# Altium schematic sheet Top.SchDoc(U_Top)
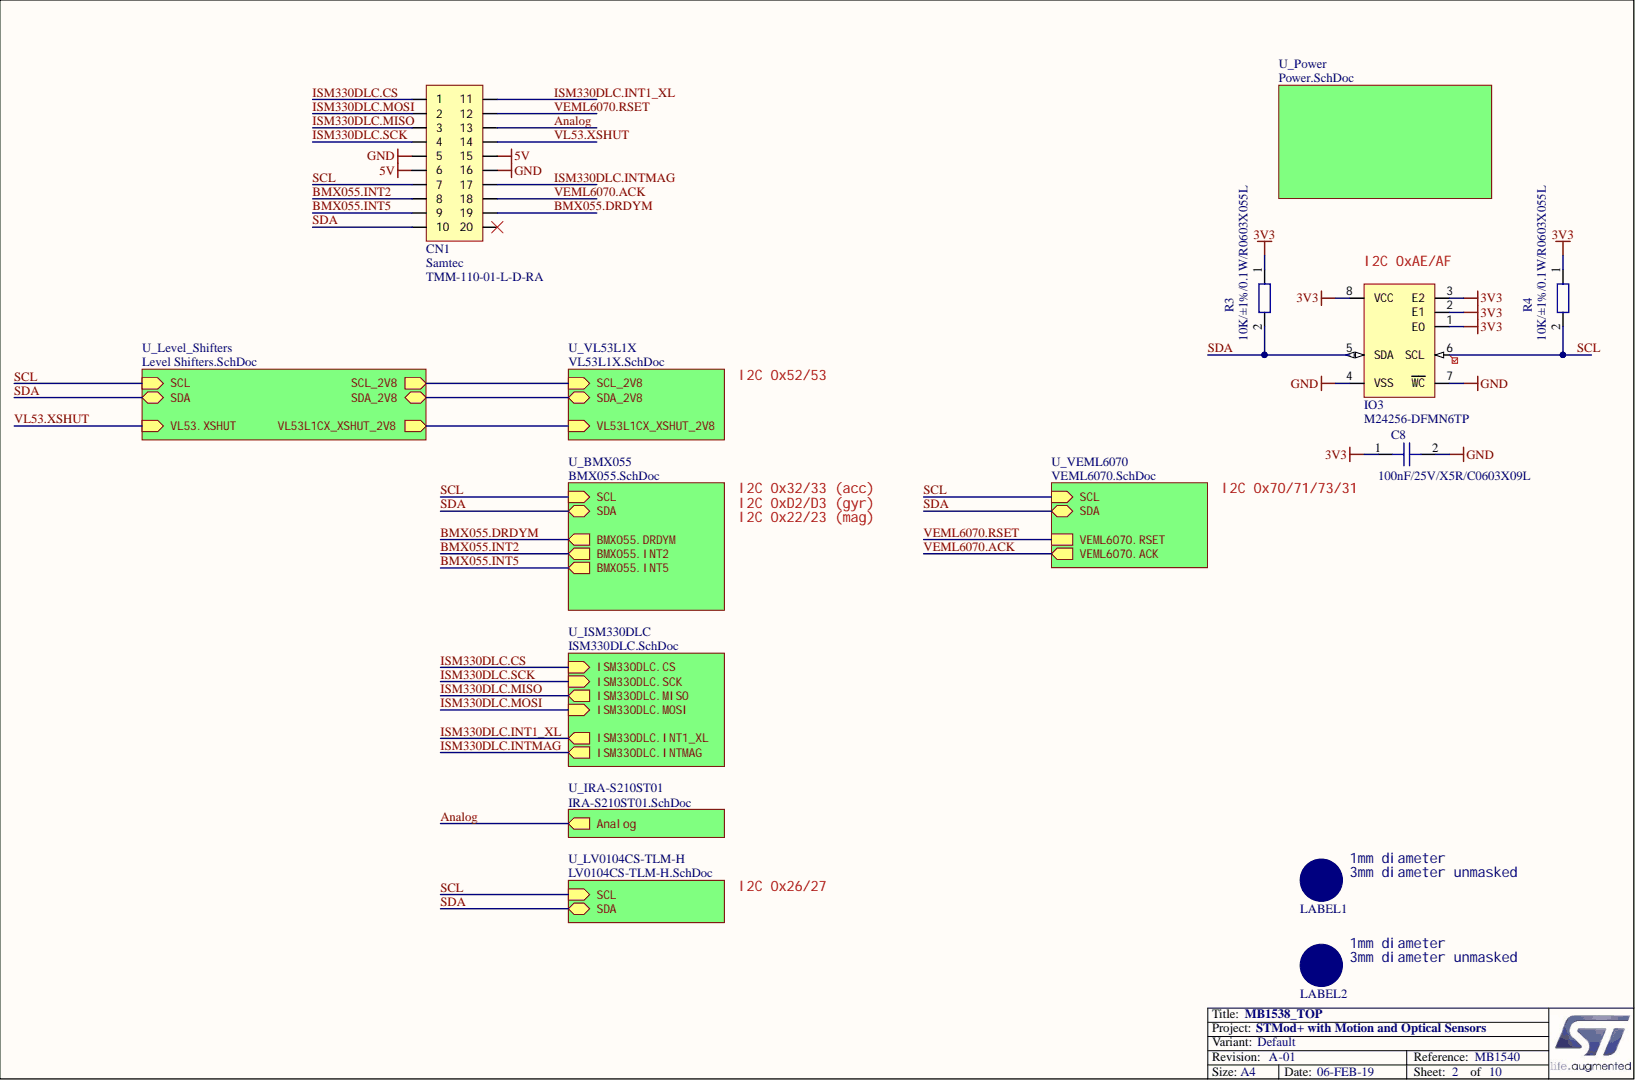
[diagram p0: Top.SchDoc]

## Parts
C8  100nF/25V/X5R/C0603X09L  [C0603X09L]
CN1  Manufacturer: Samtec  [TMM-110-01-L-D-RA]
IO3  M24256-DFMN6TP  [ST_SO8]
LABEL1  (no value)  [fiducial_1.0mm]
LABEL2  (no value)  [fiducial_1.0mm]
R3  10K/±1%/0.1W/R0603X055L  [R0603X055L]
R4  10K/±1%/0.1W/R0603X055L  [R0603X055L]

## Nets
3V3: C8.1 IO3.1(E0) IO3.2(E1) IO3.3(E2) IO3.8(VCC) R3.1 R4.1
5V: CN1.6 CN1.15
GND: C8.2 CN1.5 CN1.16 IO3.4(VSS) IO3.7(WC)
Analog: CN1.13
BMX055.DRDYM: CN1.19
BMX055.INT2: CN1.8
BMX055.INT5: CN1.9
ISM330DLC.CS: CN1.1
ISM330DLC.INT1_XL: CN1.11
ISM330DLC.INTMAG: CN1.17
ISM330DLC.MISO: CN1.3
ISM330DLC.MOSI: CN1.2
ISM330DLC.SCK: CN1.4
SCL: CN1.7 IO3.6(SCL) R4.2
SDA: CN1.10 IO3.5(SDA) R3.2
VEML6070.ACK: CN1.18
VEML6070.RSET: CN1.12
VL53.XSHUT: CN1.14
NetCN1_20: CN1.20
SCL_2V8:
SDA_2V8:
VL53L1CX_XSHUT_2V8:

## Library data of the parts
C8 = Capacitor_C_generic_100nF_25V_X5R_C0603X09L_STD__GRM188R61E104KA01: 100nF/25V/X5R/C0603; Value: 100nF; Tolerance: ±10%; Temp Min [DegC]: -55; Temp Max [DegC]: 85; SMT Assembly: YES; PkgAssyCode: C0603; Grade: STD; DielectricMaterial: X5R; DCRated: 25V; Database Table Name: c_smd_0603; Supplier Part Number 1: 81-GRM188R61E104KA1D; Supplier 4: Farnell; Supplier 3: Arrow; Supplier 2: Digi-Key; Supplier 1: Mouser; Status: new; RoHS: YES-GbD; Pin Count: 2; Part Number: Capacitor_C_generic_100nF_25V_X5R_C0603X09L_STD__GRM188R61E104KA01; Package: C0603X09L; Mounting: SMT; Manufacturer_Part_Number: 100nF/25V/X5R/C0603; Manufacturer: Generic; Footprint Class: IPC_High; ComponentLink1URL: http://www.murata.com/products/catalog/pdf/c02e.pdf; ComponentLink1Description: Datasheet; Component Kind: Standard; Component Class: Capacitor; Library Name: nas_mysql_lib.DbLib
CN1 = Connector_Header 10X2_123-20_20_Plug_TMM-110-01-L-D-RA_STD_TH_black___SamtecTMM-110-01-L-D-RA: Header, 20-Pin, Dual row; Manufacturer Series: TMM; Temp Min [DegC]: -55; Temp Max [DegC]: 125; SMT Assembly: NO; NumberOfContacts: 20; Grade: STD; Gender: Plug; Database Table Name: cn; CurrentRating: 3.2A; Supplier Part Number 1: SAM10228-ND; Supplier 4: Farnell; Supplier 3: Arrow; Supplier 2: Mouser; Supplier 1: Digi-Key; Status: new; RoHS: YES-GbD; Pin Count: 20; Part Number: Connector_Header 10X2_123-20_20_Plug_TMM-110-01-L-D-RA_STD_TH_black___SamtecTMM-110-01-L-D-RA; Packaging: Bulk1; Package: TMM-110-01-L-D-RA; Mounting: TH; Manufacturer_Part_Number: TMM-110-01-L-D-RA; Footprint Class: IPC_High; ComponentLink1URL: http://suddendocs.samtec.com/prints/tmm-1xx-xx-xx-x-ra-xxx-mkt.pdf; ComponentLink1Description: Datasheet; Component Kind: Standard; Component Class: Connector; Color: black; Library Name: nas_mysql_lib.DbLib
IO3 = EEPROM_ST_M24256-DFMN6TP_: Manufacturer: ST; Temp Min [DegC]: -40; Temp Max [DegC]: 85; SMT Assembly: YES; Grade: STD; Database Table Name: io; Supplier Part Number 2: 497-15743-1-ND; Supplier 4: Farnell; Supplier 3: Arrow; Supplier 2: Digi-Key; Supplier 1: Mouser; Status: new; RoHS: YES-GbD; PkgAssyCode: ST_SO8; Pin Count: 8; Partnumber: M24256-DFMN6TP; Part Number: EEPROM_ST_M24256-DFMN6TP_; Package: ST_SO8; Mounting: SMT; Manufacturer_Part_Number: M24256-DFMN6TP; Footprint Class: IPC_High; Description: M24256-DFMN6TP; ComponentLink1URL: https://www.st.com/content/ccc/resource/technical/document/datasheet/59/05/c9/5b/7b/41/48/b6/CD00259167.pdf/files/CD00259167.pdf/jcr:content/translations/en.CD00259167.pdf; ComponentLink1Description: Datasheet; Component Kind: Standard; Component Class: EEPROM; Library Name: nas_mysql_lib.DbLib
LABEL1 LABEL2 = Fiducial_1mm_circular: SMT Assembly: NO; Database Table Name: fabric; Status: new; RoHS: YES; Pin Count: 1; Part Number: Fiducial_1mm_circular; Package: fiducial_1.0mm; Mounting: NONE; Footprint Class: STD; Component Kind: Standard; Component Class: Fiducial; Library Name: nas_mysql_lib.DbLib
R3 R4 = Resistor_R_generic_10K_±1%_0.1W_±100ppm/DegC_R0603X055L_STD__YageoRC: 10K/±1%/0.1W/R0603; Value: 10K; Tolerance: ±1%; Temp Min [DegC]: -55; Temp Max [DegC]: 155; SMT Assembly: YES; PkgAssyCode: R0603; MaxPower: 0.1W; Grade: STD; Database Table Name: r_smd_0603; ThermalDrift: ±100ppm/DegC; Supplier Part Number 2: 603-RC0603FR-0710KL; Supplier Part Number 1: 311-10.0KHRCT-ND; Supplier 4: Farnell; Supplier 3: Arrow; Supplier 2: Mouser; Supplier 1: Digi-Key; Status: new; RoHS: YES-GbD; Pin Count: 2; Part Number: Resistor_R_generic_10K_±1%_0.1W_±100ppm/DegC_R0603X055L_STD__YageoRC; Package: R0603X055L; Mounting: SMT; Manufacturer_Part_Number: 10K/±1%/0.1W/R0603; Manufacturer: Generic; Footprint Class: IPC_High; ComponentLink1URL: http://www.yageo.com/documents/recent/PYu-RC_Group_51_RoHS_L_7.pdf; ComponentLink1Description: Datasheet; Component Kind: Standard; Component Class: Resistor; Library Name: nas_mysql_lib.DbLib
